# Altium schematic sheet LCD.SchDoc
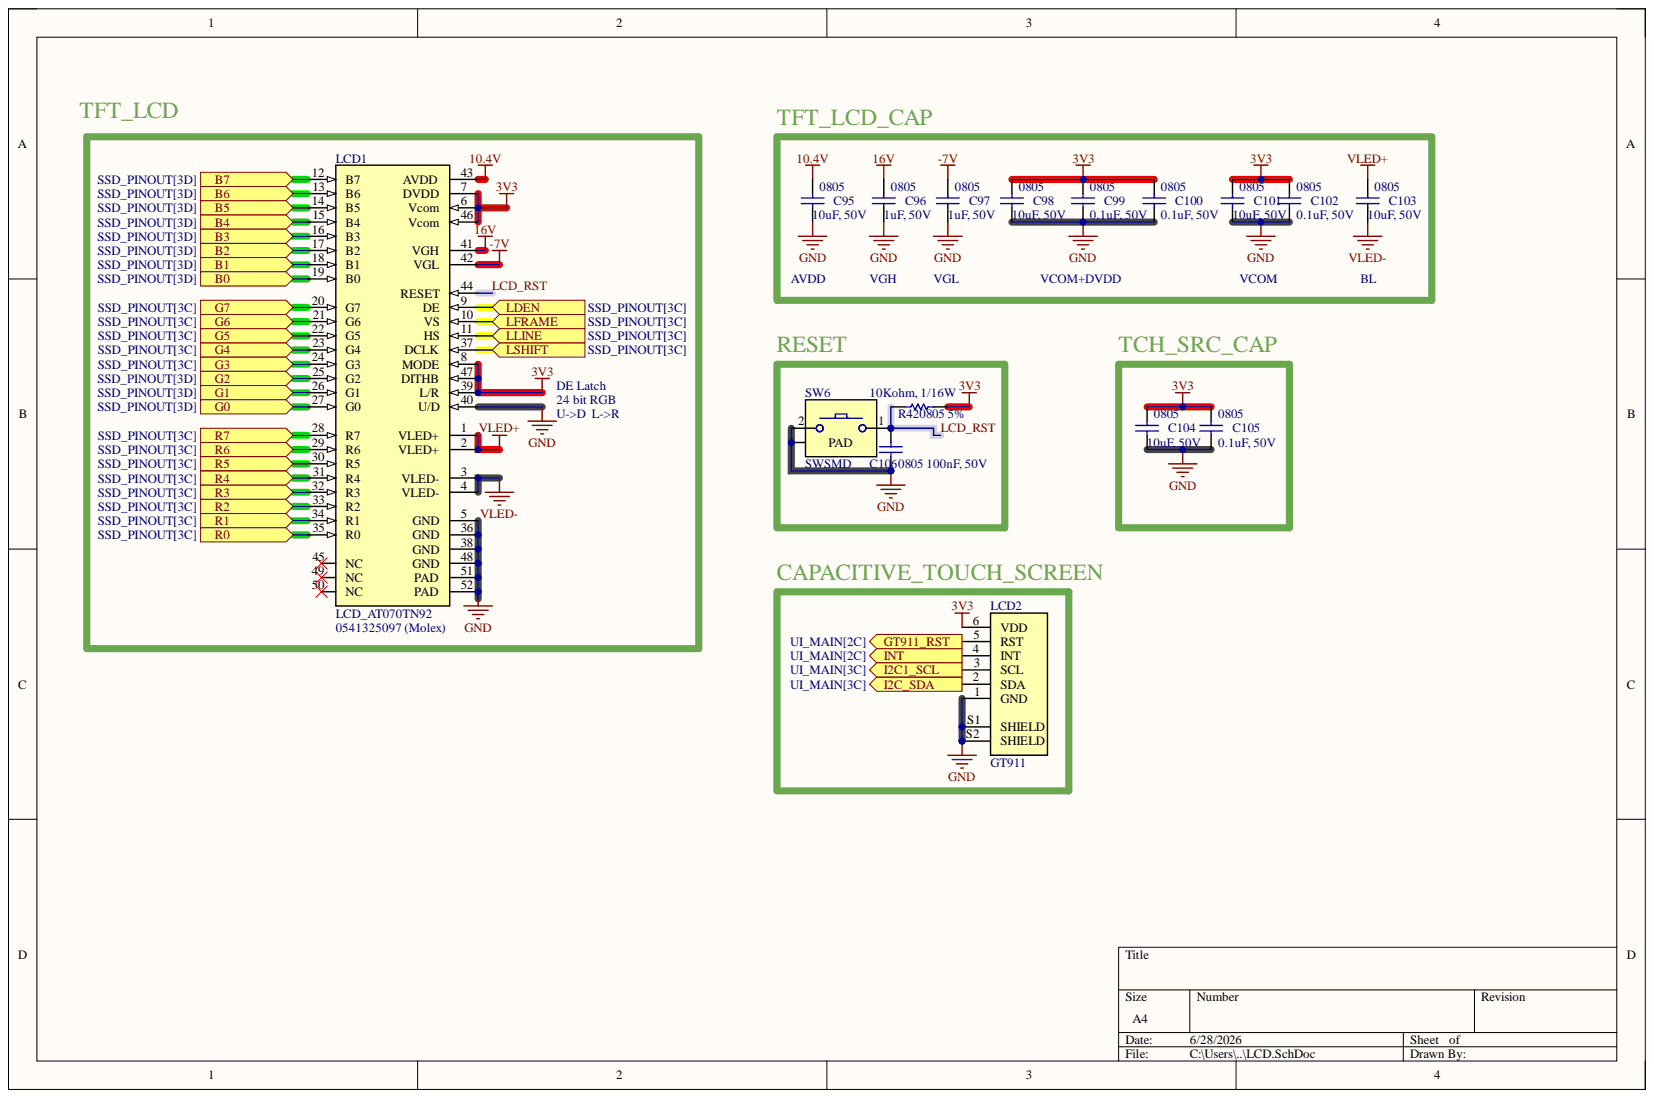
[diagram p0: LCD.SchDoc]

## Parts
C95  10uF, 50V  Package: 0805  [C_0805]
C96  1uF, 50V  Package: 0805  [C_0805]
C97  1uF, 50V  Package: 0805  [C_0805]
C98  10uF, 50V  Package: 0805  [C_0805]
C99  0.1uF, 50V  Package: 0805  [C_0805]
C100  0.1uF, 50V  Package: 0805  [C_0805]
C101  10uF, 50V  Package: 0805  [C_0805]
C102  0.1uF, 50V  Package: 0805  [C_0805]
C103  10uF, 50V  Package: 0805  [C_0805]
C104  10uF, 50V  Package: 0805  [C_0805]
C105  0.1uF, 50V  Package: 0805  [C_0805]
C106  100nF, 50V  Package: 0805  [C_0805]
LCD1  LCD_AT070TN92  Connector Part Number: 0541325097 (Molex)  [CONN_LCD_AT070TN90]
LCD2  GT911  [GT911 TS FPC]
R42  10Kohm, 1/16W  Acc: 5%  Package: 0805  [R_0805]
SW6  SWSMD  [SW_SMD]

## Ports
B0, B1, B2, B3, B4, B5, B6, B7, G0, G1, G2, G3, G4, G5, G6, G7, GT911_RST, I2C1_SCL, I2C_SDA, INT, LDEN, LFRAME, LLINE, LSHIFT, R0, R1, R2, R3, R4, R5, R6, R7

## Nets
3V3: C98.2 C99.2 C100.2 C101.2 C102.2 C104.2 C105.2 LCD1.6(Vcom) LCD1.7(DVDD) LCD1.8(MODE) LCD1.39(L/R) LCD1.46(Vcom) LCD1.47(DITHB) LCD2.6(VDD) R42.1
10.4V: C95.2 LCD1.43(AVDD)
16V: C96.2 LCD1.41(VGH)
-7V: C97.2 LCD1.42(VGL)
GND: C95.1 C96.1 C97.1 C98.1 C99.1 C100.1 C101.1 C102.1 C104.1 C105.1 C106.1 LCD1.5(GND) LCD1.36(GND) LCD1.38(GND) LCD1.40(U/D) LCD1.48(GND) LCD1.51(PAD) LCD1.52(PAD) LCD2.1(GND) LCD2.S1(SHIELD) LCD2.S2(SHIELD) SW6.0 SW6.2
VLED+: C103.2 LCD1.1(VLED+) LCD1.2(VLED+)
VLED-: C103.1 LCD1.3(VLED-) LCD1.4(VLED-)
B0: LCD1.19(B0) ; port B0
B1: LCD1.18(B1) ; port B1
B2: LCD1.17(B2) ; port B2
B3: LCD1.16(B3) ; port B3
B4: LCD1.15(B4) ; port B4
B5: LCD1.14(B5) ; port B5
B6: LCD1.13(B6) ; port B6
B7: LCD1.12(B7) ; port B7
G0: LCD1.27(G0) ; port G0
G1: LCD1.26(G1) ; port G1
G2: LCD1.25(G2) ; port G2
G3: LCD1.24(G3) ; port G3
G4: LCD1.23(G4) ; port G4
G5: LCD1.22(G5) ; port G5
G6: LCD1.21(G6) ; port G6
G7: LCD1.20(G7) ; port G7
GT911_RST: LCD2.5(RST) ; port GT911_RST
I2C1_SCL: LCD2.3(SCL) ; port I2C1_SCL
I2C_SDA: LCD2.2(SDA) ; port I2C_SDA
INT: LCD2.4(INT) ; port INT
LCD_RST: C106.2 LCD1.44(RESET) R42.2 SW6.1
LDEN: LCD1.9(DE) ; port LDEN
LFRAME: LCD1.10(VS) ; port LFRAME
LLINE: LCD1.11(HS) ; port LLINE
LSHIFT: LCD1.37(DCLK) ; port LSHIFT
R0: LCD1.35(R0) ; port R0
R1: LCD1.34(R1) ; port R1
R2: LCD1.33(R2) ; port R2
R3: LCD1.32(R3) ; port R3
R4: LCD1.31(R4) ; port R4
R5: LCD1.30(R5) ; port R5
R6: LCD1.29(R6) ; port R6
R7: LCD1.28(R7) ; port R7

Not connected: LCD1 45(NC) 49(NC) 50(NC)

## Library data of the parts
C95-C106 = CAP_0805: Manufacturer 1: Vishay; Manufacturer Part Number 1: CAP0805; Component Kind: Standard; Datasheet: https://www.mouser.de/datasheet/2/447/PYu_RC_Group_51_RoHS_L_11-1984063.pdf; Description: CAP_0805; Library Name: DisplayLib.IntLib; Supplier 1: Thegioiic; Supplier Link: N/a
  C95 C98 C101 C103 C104: Value: 10uF, 50V
  C96 C97: Value: 1uF, 50V
  C99 C100 C102 C105: Value: 0.1uF, 50V
  C106: Value: 100nF, 50V
LCD1 = LCD_AT070TN92: LCD 7 inch (800 x 3(RGB) x 480) RES  __NOTE THAT THIS IS FFC 50PINS CONN w/ THE LCD FOOTPRINT!__; Manufacturer 1: INNOLUXDISPLAY Corp.; Component Kind: Standard; Datasheet: https://mm.digikey.com/Volume0/opasdata/d220001/medias/docus/530/AT070TN92_Jan12_2009_spec.pdf; Library Name: DisplayLib.IntLib; Manufacturer Part Number 1: AT070TN92; Supplier 1: Thegioiic (Out of stock); Supplier Link: https://www.thegioiic.com/lcd-7inch-rbg-800x480-at070tn92-tft-rgb-innolux
LCD2 = GT911 Cap Touch Screen: 7 ”Capacitive Touch Panel; Manufacturer 1: Ronbo Electronics Limited; Manufacturer Part Number 1: N/a; Component Kind: Standard; Datasheet: https://www.fortec-integrated.de/fileadmin/pdf/produkte/Touchcontroller/DDGroup/GT911_Datasheet.pdf; Library Name: DisplayLib.IntLib; Supplier 1: Made-in-China; Supplier Link: https://vi.made-in-china.com/co_ronboelectronics/product_7-0-Inch-Big-Size-Cover-Tempered-Glass-Projected-Capacitive-Touch-Panel-Multi-Touch-Screen_rgireihug.html?pv_id=1js43nnncc14&faw_id=1js43nokudbb&bv_id=1js43oog8196&pbv_id=1js43npif719z
R42 = RES_0805: Manufacturer 1: Vishay; Manufacturer Part Number 1: RES0805; Component Kind: Standard; Datasheet: https://www.mouser.de/datasheet/2/447/PYu_RC_Group_51_RoHS_L_11-1984063.pdf; Description: RES_0805; Library Name: DisplayLib.IntLib; Supplier 1: Thegioiic; Supplier Link: N/a; Value: 10Kohm, 1/16W
SW6 = SWSMD: SW_SMD; Manufacturer 1: OEM; Manufacturer Part Number 1: N/a; Component Kind: Standard; Datasheet: N/a; Library Name: DisplayLib.IntLib; Supplier 1: Thegioiic; Supplier Link: https://www.thegioiic.com/nut-nhan-3x6mm-cao-3-5mm-4-chan-smd-chinh-ngang
